# Altium schematic sheet MasterDMX.SchDoc(MasterDMX)
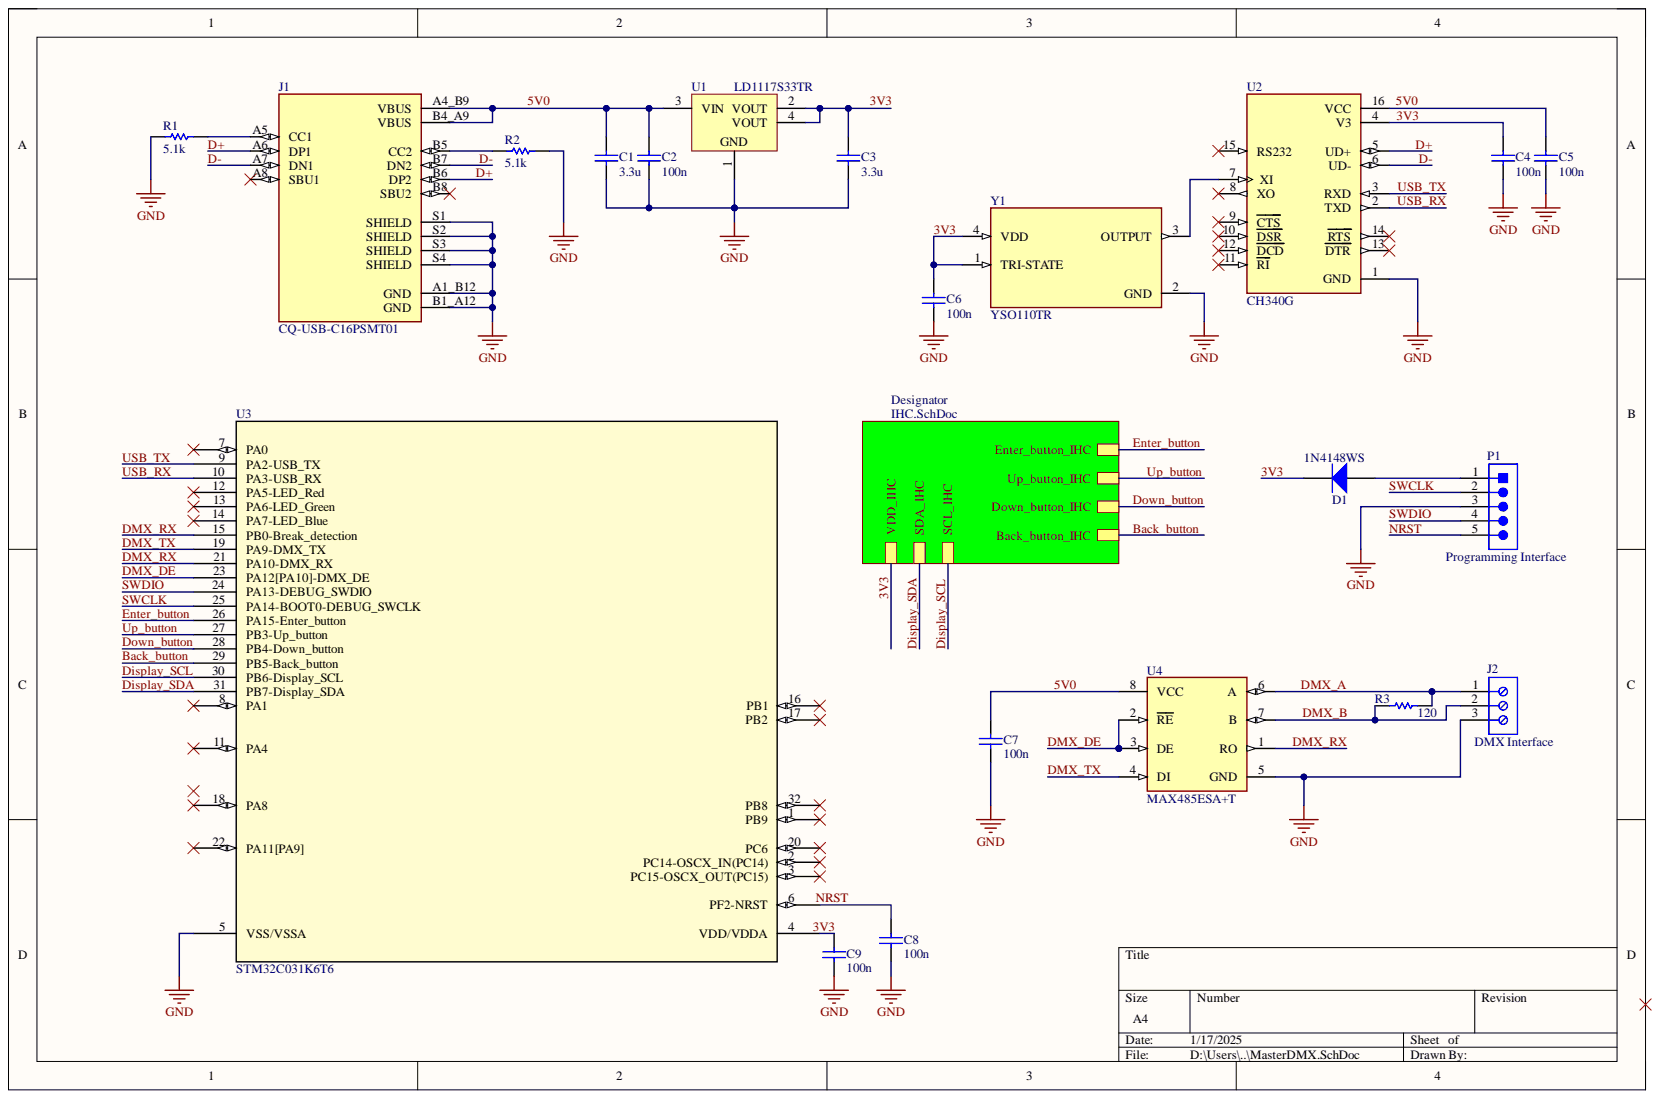
[diagram p0: MasterDMX.SchDoc]

## Parts
C1  3.3u  [C2012[0805]]
C2  100n  [C2012[0805]]
C3  3.3u  [C2012[0805]]
C4  100n  [C2012[0805]]
C5  100n  [C2012[0805]]
C6  100n  [C2012[0805]]
C7  100n  [C2012[0805]]
C8  100n  [C2012[0805]]
C9  100n  [C2012[0805]]
D1  1N4148WS  [FAIR-SOD-323_V]
J1  CQ-USB-C16PSMT01  [SHENZHEN-CHUANGQIN-TECHNOLOGY_CQ-USB-C16PSMT01]
J2  DMX Interface  [691214110003]
P1  Programming Interface  [FCI-77311-5_V]
R1  5.1k  [R2012[0805]]
R2  5.1k  [R2012[0805]]
R3  120  [R2012[0805]]
U1  LD1117S33TR  [STM-SOT-223_M]
U2  CH340G  [SOIC127P600X180-16N]
U3  STM32C031K6T6  [LQFP32_7X7_STM]
U4  MAX485ESA+T  [FP-S8-2-MFG]
Y1  YSO110TR  [OSC_ECS-TXO-2016-33-120-TR]

## Nets
GND: C1.1 C2.1 C3.1 C4.1 C5.1 C6.1 C7.1 C8.1 C9.1 J1.A1_B12(GND) J1.B1_A12(GND) J1.S1(SHIELD) J1.S2(SHIELD) J1.S3(SHIELD) J1.S4(SHIELD) J2.3 P1.3 R1.1 R2.2 U1.1 U2.1(GND) U3.5(VSS/VSSA) U4.5(GND) Y1.2(GND)
3V3: C3.2 C4.2 C6.2 C9.2 D1.2 U1.2(VOUT) U1.4(VOUT) U2.4(V3) U3.4(VDD/VDDA) Y1.1(TRI-STATE) Y1.4(VDD)
5V0: C1.2 C2.2 C5.2 C7.2 J1.A4_B9(VBUS) J1.B4_A9(VBUS) U1.3(VIN) U2.16(VCC) U4.8(VCC)
Back_button: U3.29(PB5-Back_button)
D+: J1.A6(DP1) J1.B6(DP2) U2.5(UD+)
D-: J1.A7(DN1) J1.B7(DN2) U2.6(UD-)
Display_SCL: U3.30(PB6-Display_SCL)
Display_SDA: U3.31(PB7-Display_SDA)
DMX_A: J2.1 R3.1 U4.6(A)
DMX_B: J2.2 R3.2 U4.7(B)
DMX_DE: U3.23(PA12[PA10]-DMX_DE) U4.2(RE) U4.3(DE)
DMX_RX: U3.15(PB0-Break_detection) U3.21(PA10-DMX_RX) U4.1(RO)
DMX_TX: U3.19(PA9-DMX_TX) U4.4(DI)
Down_button: U3.28(PB4-Down_button)
Enter_button: U3.26(PA15-Enter_button)
NRST: C8.2 P1.5 U3.6(PF2-NRST)
SWCLK: P1.2 U3.25(PA14-BOOT0-DEBUG_SWCLK)
SWDIO: P1.4 U3.24(PA13-DEBUG_SWDIO)
Up_button: U3.27(PB3-Up_button)
USB_RX: U2.2(TXD) U3.10(PA3-USB_RX)
USB_TX: U2.3(RXD) U3.9(PA2-USB_TX)
NetD1_1: D1.1 P1.1
NetJ1_A5: J1.A5(CC1) R1.2
NetJ1_A8: J1.A8(SBU1)
NetJ1_B5: J1.B5(CC2) R2.1
NetJ1_B8: J1.B8(SBU2)
NetU2_7: U2.7(XI) Y1.3(OUTPUT)
NetU2_8: U2.8(XO)
NetU2_9: U2.9(CTS)
NetU2_10: U2.10(DSR)
NetU2_11: U2.11(RI)
NetU2_12: U2.12(DCD)
NetU2_13: U2.13(DTR)
NetU2_14: U2.14(RTS)
NetU2_15: U2.15(RS232)
NetU3_1: U3.1(PB9)
NetU3_2: U3.2(PC14-OSCX_IN(PC14))
NetU3_3: U3.3(PC15-OSCX_OUT(PC15))
NetU3_7: U3.7(PA0)
NetU3_8: U3.8(PA1)
NetU3_11: U3.11(PA4)
NetU3_12: U3.12(PA5-LED_Red)
NetU3_13: U3.13(PA6-LED_Green)
NetU3_14: U3.14(PA7-LED_Blue)
NetU3_16: U3.16(PB1)
NetU3_17: U3.17(PB2)
NetU3_18: U3.18(PA8)
NetU3_20: U3.20(PC6)
NetU3_22: U3.22(PA11[PA9])
NetU3_32: U3.32(PB8)

## Library data of the parts
C1-C9 = C: Capacitor; Value: 1; Component Kind: Standard; Library Name: Basic Library.IntLib; Simulation: CAP
D1 = CMP-004-00001-2: Small Signal Diode, 75 V, 0.15 A, -55 to 150 degC, 2-Pin SOD-323, RoHS, Tape and Reel; Manufacturer: Fairchild; Capacitance: -323f; Forward Current: 150mA; Forward Voltage: 1V; Peak Reverse Current: 5uA; Recovery Time: 4ns; Case/Package: SOD-323; Component Kind: Standard; Datasheet URL: https://www.fairchildsemi.com/datasheets/1N/1N4148WS.pdf; Datasheet Version: Rev. 1.2, 03/2015; Manufacturer URL: https://www.fairchildsemi.com/; Max Operating Temperature: 150°C; Min Operating Temperature: -55°C; Mounting Technology: SM; Package Description: 2-Pin Small Outline Diode Flat Lead, Body 1.7 x 1.25 mm; Package Quantity: 3000; Package Reference: SOD-323; Packaging: TapeandReel; Pins: 2; RoHS Compliant: True; Library Name: Guilherme Silveira
J1 = CQ-USB-C16PSMT01: C Type USB Type-C Female Plug 16 Pin Connector Power Charger Jack Port; MF: Shenzen Chuangqin Technology; Availability: Unavailable; Check_prices: https://www.snapeda.com/parts/CQ-USB-C16PSMT01/Shenzen%20Chuangqin%20Technology/view-part/5798994/?ref=eda; Component Kind: Standard; Library Name: CQ-USB-C16PSMT01.IntLib; MP: CQ-USB-C16PSMT01; Package: Package; Price: None; SnapEDA_Link: https://www.snapeda.com/parts/CQ-USB-C16PSMT01/Shenzen%20Chuangqin%20Technology/view-part/5798994/?ref=snap
J2 = CMP-1502-02466-2: NexarPartId: 18818289; Current: 10A; Number of Contacts: 3; Number of Levels: 1; Pitch: 3.5052mm; Plating: Brass, Steel; Stripping Length: -6mm; Voltage: 300V; Wire Gauge (Max): 24AWG; Wire Gauge (Min): 16AWG; Color: Blue, Green; Component Kind: Standard; Contact Material: Brass; Contact Plating: Brass, Tin; Current Rating: 10A; Height: 12.2mm; Housing Material: Nylon; Lead Pitch: 3.5mm; Length: 7.4mm; Library Name: Altium Content Vault; Max Operating Temperature: 105°C; Min Operating Temperature: -40°C; Mount: Through Hole; Number of Pins: 3; Number of Positions Per Level: 3; Packaging: Box; REACH SVHC: Unknown; RoHS: Compliant; Termination: Screw; Voltage Rating: 300V; Width: 10.9mm; Wire/Cable Gauge: 24AWG
P1 = CMP-002-00021-2: Male Header, Pitch 2.54 mm, 1 x 5 Position, Height 16.24 mm, Tail Length 3.05 mm, -65 to 125 degC, RoHS, Tape and Reel; Manufacturer: FCI Electronics; Case/Package: 77311-5; Component Kind: Standard; Connector Type: Header; Datasheet URL: http://portal.fciconnect.com/Comergent//fci/drawing/77311.pdf; Datasheet Version: Rev. CK, 07/2016; Gender: Male; Manufacturer URL: http://portal.fciconnect.com/; Mounting Technology: TH; Package Description: Male Header, Pitch 2.54 mm, 1 x 5 Position, Height 16.24 mm, Tail Length 3.05 mm; Package Reference: 77311-5; RoHS Compliant: Unknown; Library Name: Guilherme Silveira
R1-R3 = R: Resistor; Value: 0; Component Kind: Standard; Library Name: Basic Library.IntLib; Signal Integrity: Res Semi; Simulation: RES
U1 = CMP-019-00019-3: Low Drop Positive Voltage Regulator, 800 mA, Fixed 3.3 V Output, 0 to 125 degC, 3-Pin TO-220, RoHS, Tape and Reel; Manufacturer: STMicroelectronics; Dropout Voltage: 1.1V; Max Input Voltage: 15V; Max Ouput Current: 1.3A; Min Input Voltage: 4.75V; Nominal Output Voltage: 3.3V; Output Current: 950mA; Outputs: 1; Power: 12W; Case/Package: TO-261-4; Component Kind: Standard; Datasheet URL: http://www.farnell.com/datasheets/1776449.pdf; Datasheet Version: Rev. 33, 11/2013; Manufacturer URL: http://www.st.com/; Max Operating Temperature: 125°C; Max Supply Voltage: 15V; Min Operating Temperature: 0°C; Min Supply Voltage: 4.75V; Mounting Technology: SM; Output Voltage: 3.3V; Package Description: 4-Pin Small Outline Transistor, Body 6.5 x 3.5 mm, Pitch 2.3 mm; Package Quantity: 2500; Package Reference: SOT-223; Packaging: TapeandReel; Pins: 3; RoHS Compliant: True; Library Name: Guilherme Silveira
U2 = CH340G: USB Interface Integrated Circuit; MF: WCH; Availability: Not in stock; Check_prices: https://www.snapeda.com/parts/Ch340G/WCH/view-part/?ref=eda; Component Kind: Standard; Library Name: CH340G.IntLib; MP: Ch340G; Package: None; Price: None; SnapEDA_Link: https://www.snapeda.com/parts/Ch340G/WCH/view-part/?ref=snap
U3 = STM32C031K6T6: No Description Available; Mfr_Name: STMicroelectronics; Component Kind: Standard; Library Name: STM32C031K6T6.IntLib; RefDes: RefDes; Type: Type
U4 = CMP-015-00008-1: Line Transceiver, 1 Func, 1 Driver, 1 Rcvr, CMOS, PDSO8; Max Supply Voltage: 5.25V; Min Supply Voltage: 4.75V; Component Kind: Standard; Max Operating Temperature: 85°C; Min Operating Temperature: -40°C; RoHS Compliant: Yes; Library Name: Guilherme Silveira
Y1 = CMP-003-000000-1: MP: ECS-TXO-2016-33-120-TR; Availability: Unavailable; Component Kind: Standard; Component Type: Standard; Design Item ID: ECS-TXO-2016-33-120-TR; Package: None; Pin Count: 4; Price: None; Source: ECS-TXO-2016-33-120-TR.SchLib; Library Name: Guilherme Silveira
